# Altium schematic sheet Sheet4.SchDoc
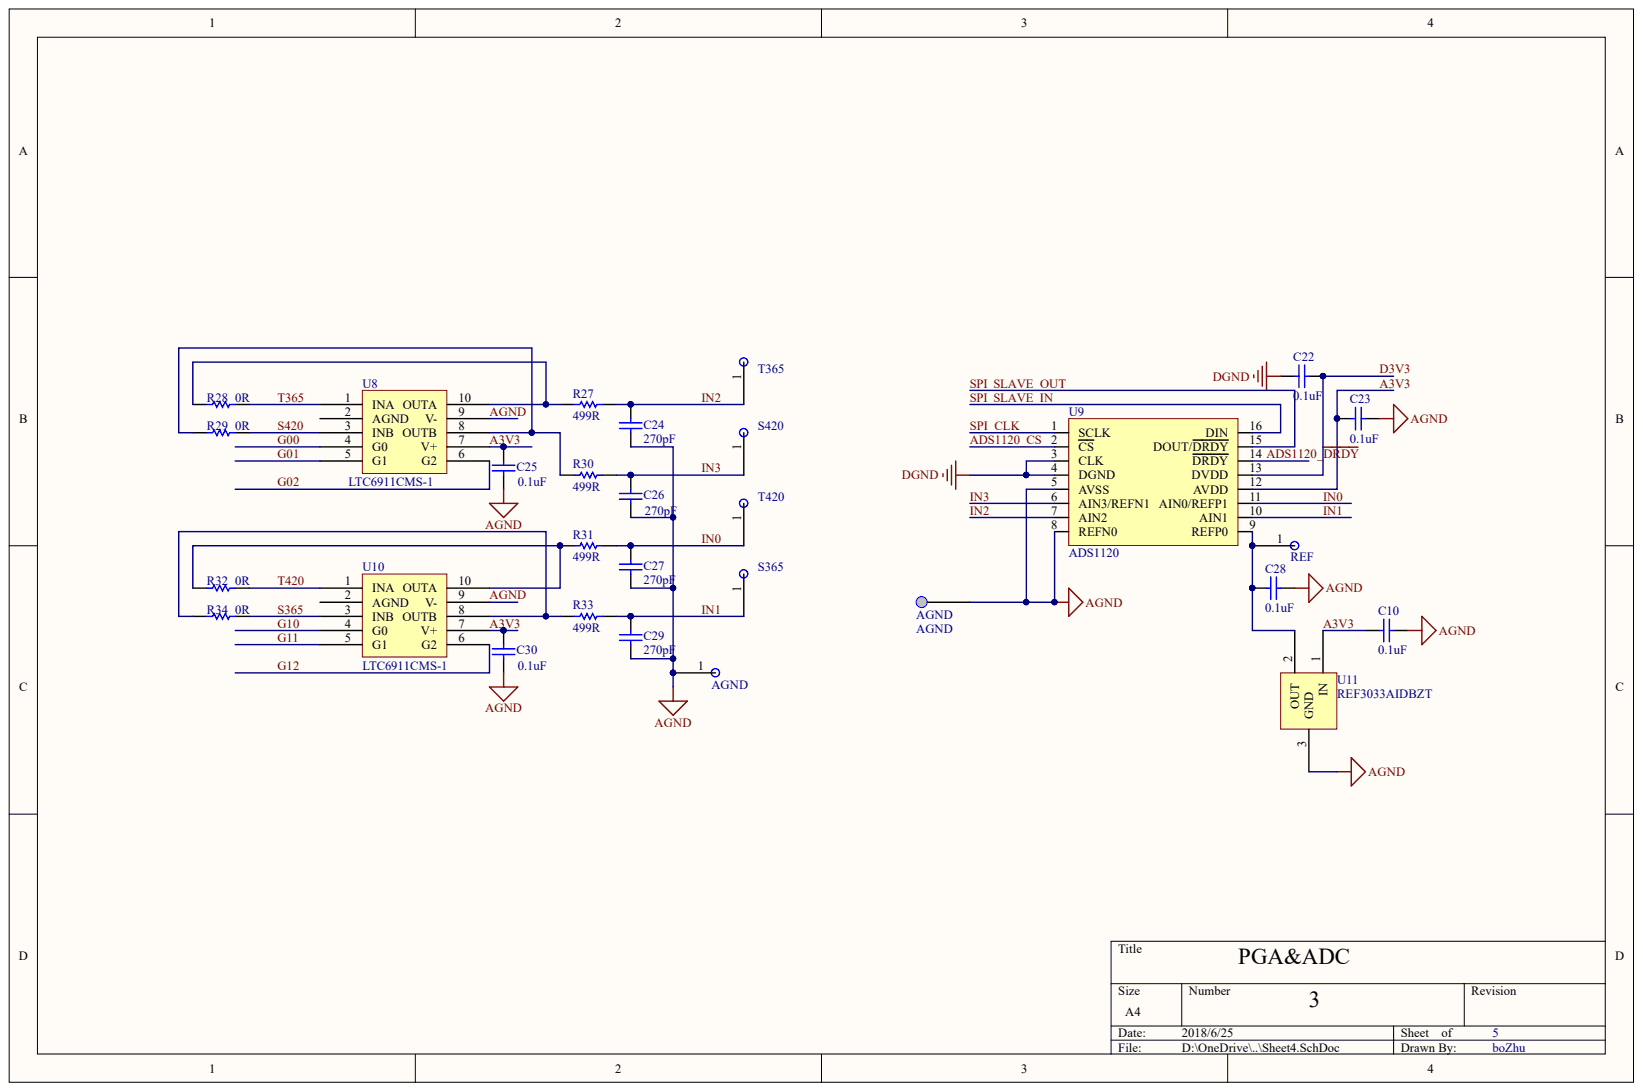
[diagram p0: Sheet4.SchDoc]

## Parts
AGND  Test Point  (value not drawn)
C10  value 0.1uF  (hidden comment: Cap)
C22  value 0.1uF  (hidden comment: Cap)
C23  value 0.1uF  (hidden comment: Cap)
C24  value 270pF  (hidden comment: Cap)
C25  value 0.1uF  (hidden comment: Cap)
C26  value 270pF  (hidden comment: Cap)
C27  value 270pF  (hidden comment: Cap)
C28  value 0.1uF  (hidden comment: Cap)
C29  value 270pF  (hidden comment: Cap)
C30  value 0.1uF  (hidden comment: Cap)
dot2  AGND
R27  value 499R  (hidden comment: Res1)
R28  value 0R  (hidden comment: Res1)
R29  value 0R  (hidden comment: Res1)
R30  value 499R  (hidden comment: Res1)
R31  value 499R  (hidden comment: Res1)
R32  value 0R  (hidden comment: Res1)
R33  value 499R  (hidden comment: Res1)
R34  value 0R  (hidden comment: Res1)
REF  Test Point  (value not drawn)
S365  Test Point  (value not drawn)
S420  Test Point  (value not drawn)
T365  Test Point  (value not drawn)
T420  Test Point  (value not drawn)
U8  LTC6911CMS-1
U9  ADS1120
U10  LTC6911CMS-1
U11  REF3033AIDBZT

## Nets
DGND: C22.1 U9.3(CLK) U9.4(DGND)
A3V3: C10.2 C23.1 C25.2 C30.2 U8.7(V+) U9.12(AVDD) U10.7(V+) U11.1
ADS1120_CS: U9.2(CS)
ADS1120_~{DRDY}: U9.14(DRDY)
AGND: AGND.1 C10.1 C23.2 C24.1 C25.1 C26.1 C27.1 C28.2 C29.1 C30.1 dot2.0 U8.9(V-) U9.5(AVSS) U9.8(REFN0) U10.9(V-) U11.3
D3V3: C22.2 U9.13(DVDD)
G00: U8.4(G0)
G01: U8.5(G1)
G02: U8.6(G2)
G10: U10.4(G0)
G11: U10.5(G1)
G12: U10.6(G2)
IN0: C27.2 R31.2 T420.1 U9.11(AIN0/REFP1)
IN1: C29.2 R33.2 S365.1 U9.10(AIN1)
IN2: C24.2 R27.2 T365.1 U9.7(AIN2)
IN3: C26.2 R30.2 S420.1 U9.6(AIN3/REFN1)
S365: R34.1 U10.3(INB)
S420: R29.1 U8.3(INB)
SPI_CLK: U9.1(SCLK)
SPI_SLAVE_IN: U9.16(DIN)
SPI_SLAVE_OUT: U9.15(DOUT/DRDY)
T365: R28.1 U8.1(INA)
T420: R32.1 U10.1(INA)
NetC28_1: C28.1 REF.1 U9.9(REFP0) U11.2
NetR27_1: R27.1 R28.2 U8.10(OUTA)
NetR29_2: R29.2 R30.1 U8.8(OUTB)
NetR31_1: R31.1 R32.2 U10.10(OUTA)
NetR33_1: R33.1 R34.2 U10.8(OUTB)

Not connected: U8 2(AGND); U10 2(AGND)

## Library data of the parts
AGND C10 C22-C30 dot2 R27-R34 REF S365 S420 T365 T420 U8-U11 = (no symbol name)
  C10 C22-C30: Published: 8-Jun-2000; LatestRevisionDate: 17-Jul-2002; LatestRevisionNote: Re-released for DXP Platform.; PackageReference: RAD-0.3
  dot2: value: AGND
  R27-R34: Published: 8-Jun-2000; LatestRevisionDate: 17-Jul-2002; LatestRevisionNote: Re-released for DXP Platform.; PackageReference: AXIAL-0.3
  C10 C22-C30 R27-R34: Publisher: Altium Limited
